# Altium schematic sheet FPGA Jtag & Uart.SchDoc("FPGA Jtag & Uart")
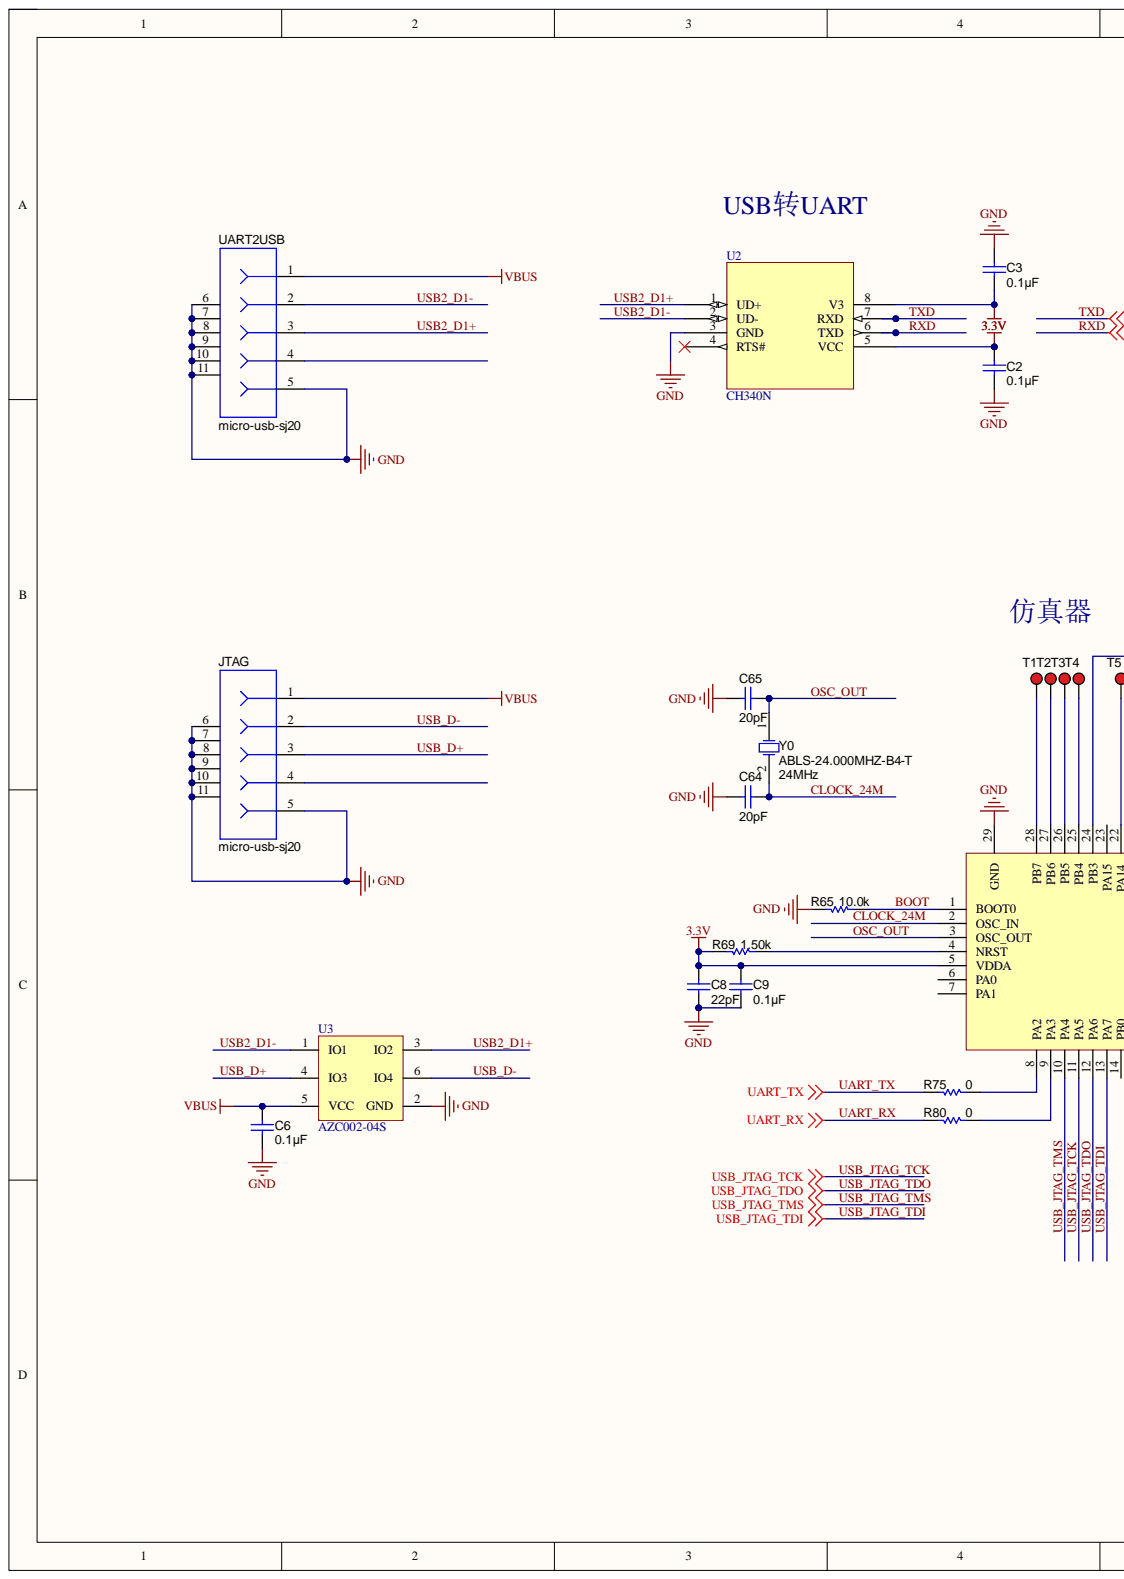
[diagram p0: FPGA Jtag & Uart.SchDoc, left]
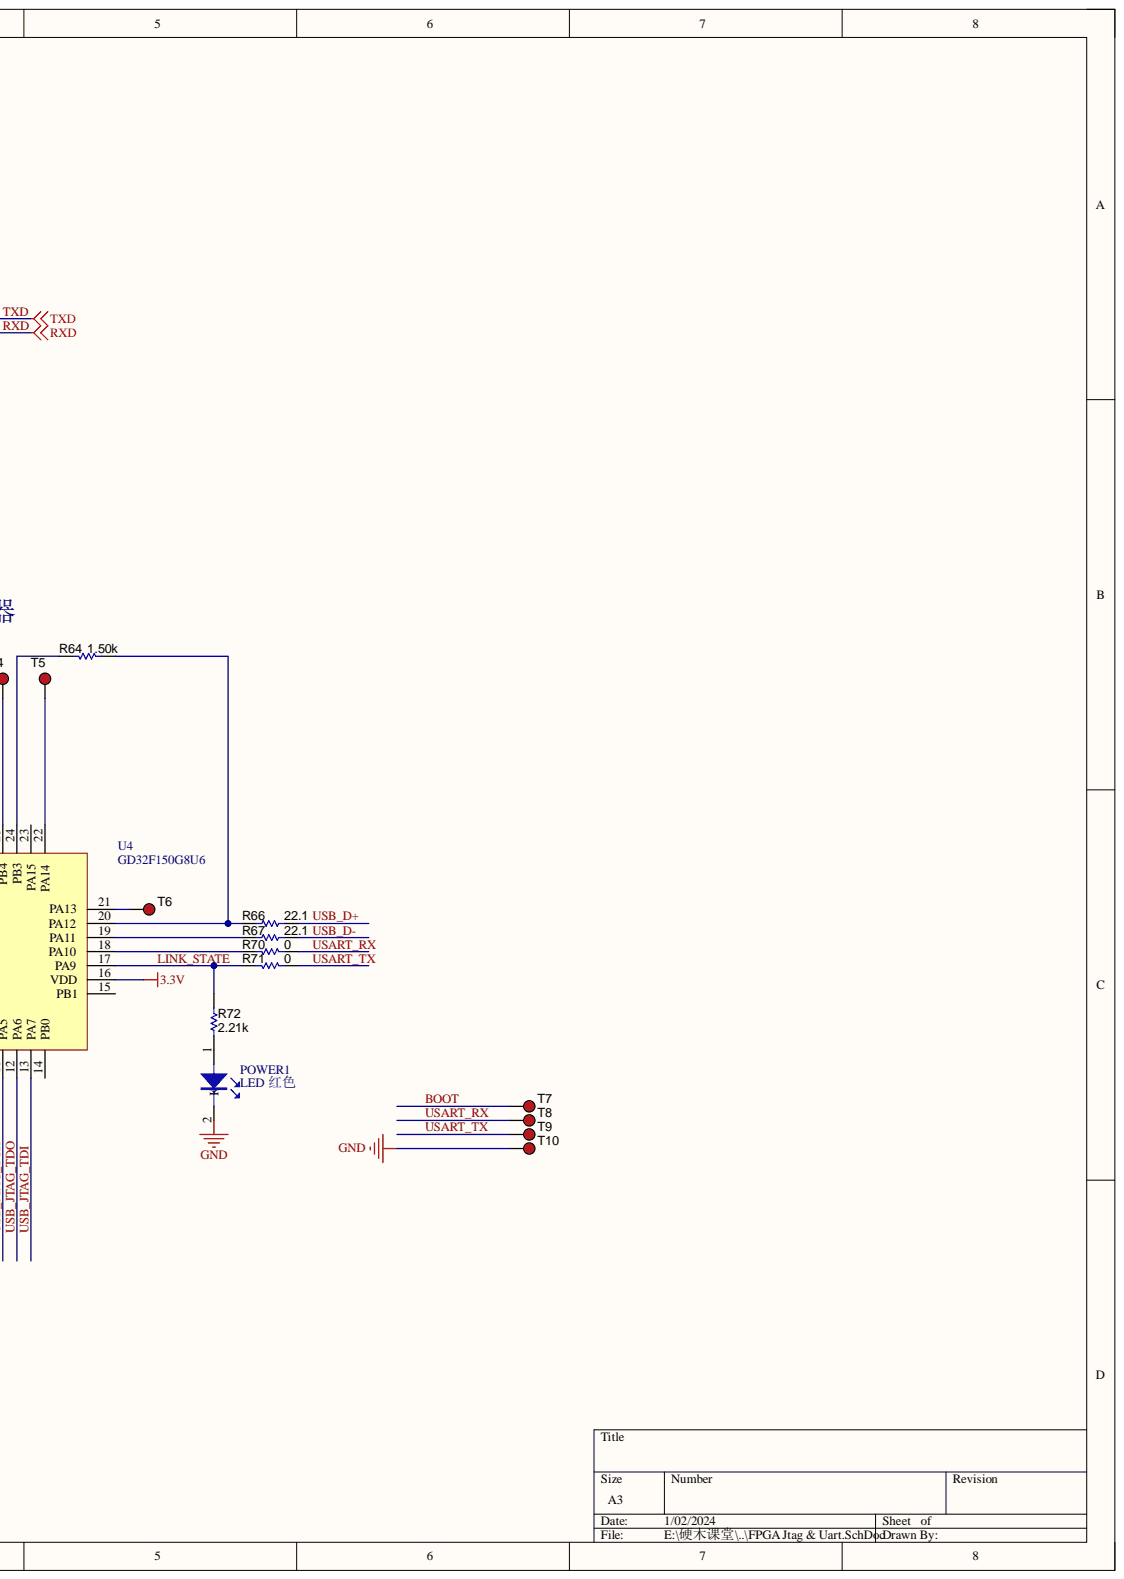
[diagram p1: FPGA Jtag & Uart.SchDoc, right]

## Parts
C2  ValueDisplayed: 0.1µF  [0402]  (hidden comment: C1005X7R1H104M)
C3  ValueDisplayed: 0.1µF  [0402]  (hidden comment: C1005X7R1H104M)
C6  ValueDisplayed: 0.1µF  [0402]  (hidden comment: C1005X7R1H104M)
C8  value 22pF  [0402]  (hidden comment: GRM1555C1H220FA01D)
C9  ValueDisplayed: 0.1µF  [0402]  (hidden comment: C1005X7R1H104M)
C64  value 20pF  [0402]  (hidden comment: GRM1555C1H200JA01D)
C65  value 20pF  [0402]  (hidden comment: GRM1555C1H200JA01D)
JTAG  micro-usb-sj20  [YQMICRO-USB-SJ20]
POWER1  LED 红色  [LED_LTST-C170]
R64  value 1.50k  [0402]  (hidden comment: CRCW04021K50FKED)
R65  value 10.0k  [0402]  (hidden comment: CRCW040210K0FKED)
R66  value 22.1  Res_ohm: 22.1  [0402]  (hidden comment: CRCW040222R1FKED)
R67  value 22.1  Res_ohm: 22.1  [0402]  (hidden comment: CRCW040222R1FKED)
R69  value 1.50k  [0402]  (hidden comment: CRCW04021K50FKED)
R70  value 0  [0402]  (hidden comment: CRCW04020000Z0ED)
R71  value 0  [0402]  (hidden comment: CRCW04020000Z0ED)
R72  value 2.21k  [0402]  (hidden comment: CRCW04022K21FKED)
R75  value 0  [0402]  (hidden comment: CRCW04020000Z0ED)
R80  value 0  [0402]  (hidden comment: CRCW04020000Z0ED)
T1  5000  [TP]  (value not drawn)
T2  5000  [TP]  (value not drawn)
T3  5000  [TP]  (value not drawn)
T4  5000  [TP]  (value not drawn)
T5  5000  [TP]  (value not drawn)
T6  5000  [TP]  (value not drawn)
T7  5000  [TP]  (value not drawn)
T8  5000  [TP]  (value not drawn)
T9  5000  [TP]  (value not drawn)
T10  5000  [TP]  (value not drawn)
U2  CH340N  [SOP8]
U3  AZC002-04S  [DBV0006A_N]
U4  GD32F150G8U6  [WQFN-28_L4.0-W4.0-P0.40-BL-EP]
UART2USB  micro-usb-sj20  [YQMICRO-USB-SJ20]
Y0  ABLS-24.000MHZ-B4-T  Frequency: 24MHz  [YQ_OSC5032]

## Nets
3.3V: C2.1 C3.2 C8.2 C9.1 R69.1 U2.5(VCC) U2.8(V3) U4.5(VDDA) U4.16(VDD)
GND: C2.2 C3.1 C6.2 C8.1 C9.2 C64.1 C65.1 JTAG.5 JTAG.6 JTAG.7 JTAG.8 JTAG.9 JTAG.10 JTAG.11 POWER1.2 R65.1 T10.1 U2.3(GND) U3.2(GND) U4.29(GND) UART2USB.5 UART2USB.6 UART2USB.7 UART2USB.8 UART2USB.9 UART2USB.10 UART2USB.11
VBUS: C6.1 JTAG.1 U3.5(VCC) UART2USB.1
BOOT: R65.2 T7.1 U4.1(BOOT0)
CLOCK_24M: C64.2 U4.2(OSC_IN) Y0.2
LINK_STATE: R71.1 R72.2 U4.17(PA9)
OSC_OUT: C65.2 U4.3(OSC_OUT) Y0.1
RXD: U2.6(TXD)
TXD: U2.7(RXD)
UART_RX: R80.1
UART_TX: R75.1
USART_RX: R70.2 T8.1
USART_TX: R71.2 T9.1
USB2_D1+: U2.1(UD+) U3.3(IO2) UART2USB.3
USB2_D1-: U2.2(UD-) U3.1(IO1) UART2USB.2
USB_D+: JTAG.3 R66.1 U3.4(IO3)
USB_D-: JTAG.2 R67.1 U3.6(IO4)
USB_JTAG_TCK: U4.11(PA5)
USB_JTAG_TDI: U4.13(PA7)
USB_JTAG_TDO: U4.12(PA6)
USB_JTAG_TMS: U4.10(PA4)
NetPOWER1_1: POWER1.1 R72.1
NetR64_1: R64.1 U4.24(PB3)
NetR64_2: R64.2 R66.2 U4.20(PA12)
NetR67_2: R67.2 U4.19(PA11)
NetR69_2: R69.2 U4.4(NRST)
NetR70_1: R70.1 U4.18(PA10)
NetR75_2: R75.2 U4.8(PA2)
NetR80_2: R80.2 U4.9(PA3)
NetT1_1: T1.1 U4.28(PB7)
NetT2_1: T2.1 U4.27(PB6)
NetT3_1: T3.1 U4.26(PB5)
NetT4_1: T4.1 U4.25(PB4)
NetT5_1: T5.1 U4.22(PA14)
NetT6_1: T6.1 U4.21(PA13)

Not connected: JTAG 4; U2 4(RTS#); U4 6(PA0) 7(PA1) 14(PB0) 15(PB1) 23(PA15); UART2USB 4

## Library data of the parts
C2 C3 C6 C9 = C1005X7R1H104M: CAP, CERM, 0.1 µF, 50 V, +/- 20%, X7R, 0402; Manufacturer: TDK; Cap_F: 0.0000001; CompType: Capacitor; Polarized: N; TaMax_C: 125; TaMin_C: -55; Tolerance_%: 20; U/M: EA; Value: 0.1uF; Value1: Unknown; Value2: 50V; VDC_V: 50; Cap_Case: 0402; Component Kind: Standard; ComponentLink1Description: Datasheet link; LatestRevisionDate: 2015-05-02; Library Name: Capacitor_Ceramic.IntLib; MountType: SMT; PackageReference: 0402; PartNumber: C1005X7R1H104M; Published: 2008-06-19; RoHS: Y; Signal Integrity: Cap; Simulation: Cap; Supplier 1: Digi-Key; Supplier 2: Farnell; Supplier Part Number 1: 445-5933-1-ND; Supplier Part Number 2: 1907278; SymbolVersion: 2008-07-09; Technology: Ceramic; TempCo: X7R; Publisher: Texas Instruments
C8 = GRM1555C1H220FA01D: CAP, CERM, 22 pF, 50 V, +/- 1%, C0G/NP0, 0402; Manufacturer: MuRata; Cap_F: 0.000000000022; CompType: Capacitor; Polarized: N; TaMax_C: 125; TaMin_C: -55; Tolerance_%: 1; U/M: EA; Value1: Unknown; Value2: 50V; VDC_V: 50; Cap_Case: 0402; Component Kind: Standard; ComponentLink1Description: Datasheet link; ComponentLink1URL: http://search.murata.co.jp/Ceramy/image/img/A01X/partnumbering_e_01.pdf; LatestRevisionDate: 2015-05-02; Library Name: Capacitor_Ceramic.IntLib; MountType: SMT; PackageReference: 0402; PartNumber: GRM1555C1H220FA01D; Published: 2008-06-19; RoHS: Y; Signal Integrity: Cap; Simulation: Cap; Supplier 1: Digi-Key; Supplier 2: Mouser; Supplier Part Number 1: 490-8589-1-ND; Supplier Part Number 2: 81-GRM1555C1H220FA1D; SymbolVersion: 2008-07-09; Technology: Ceramic; TempCo: C0G/NP0; ValueDisplayed: 22pF; Publisher: Texas Instruments
C64 C65 = GRM1555C1H200JA01D: CAP, CERM, 20 pF, 50 V, +/- 5%, C0G/NP0, 0402; Manufacturer: MuRata; Cap_F: 0.00000000002; CompType: Capacitor; Polarized: N; TaMax_C: 125; TaMin_C: -55; Tolerance_%: 5; U/M: EA; Value1: Unknown; Value2: 50V; VDC_V: 50; Cap_Case: 0402; Component Kind: Standard; ComponentLink1Description: Datasheet link; ComponentLink1URL: http://search.murata.co.jp/Ceramy/PnsearchViewAction.do?HnOFG=ON&HnNFG=ON&SEL_CNT=50&sLang=en&sKey=GRM1555C1H200JA01D&x=9&y=9&search=startwith; LatestRevisionDate: 2015-05-02; Library Name: Capacitor_Ceramic.IntLib; MountType: SMT; PackageReference: 0402; PartNumber: GRM1555C1H200JA01D; Published: 2008-06-19; RoHS: Y; Signal Integrity: Cap; Simulation: Cap; Supplier 1: Mouser; Supplier Part Number 1: 81-GRM1555C1H200JA1D; SymbolVersion: 2008-07-09; Technology: Ceramic; TempCo: C0G/NP0; ValueDisplayed: 20pF; Publisher: Texas Instruments
JTAG UART2USB = 105017-0001: Receptacle, Micro-USB-B, Right Angle, SMD; Manufacturer: Molex; CompType: Connector; SymbolCreator: a0412235; U/M: EA; Component Kind: Standard; ComponentLink1Description: Datasheet Link; ComponentLink1URL: http://www.molex.com/pdm_docs/sd/1050170001_sd.pdf; LatestRevisionDate: 2012-12-26; LatestRevisionNote: Added parts, moved some to Hardware.IntLib; Library Name: Connectors.IntLib; MountType: SMT; PackageReference: Micro USB receptacle; PartNumber: micro-usb-sj20; RoHS: Y; Supplier 1: Digi-Key; Supplier 2: Mouser; Supplier 3: Farnell; Supplier Part Number 1: WM1399CT-ND; Supplier Part Number 2: 538-105017-0001; Supplier Part Number 3: 1847433; SymbolVersion: 2012-12-19; Publisher: Texas Instruments
POWER1 = LED: LED 发光二极管; Component Kind: Standard; Library Name: WK_PCB.SchLib
R64 R69 = CRCW04021K50FKED: RES, 1.50 k, 1%, 0.063 W, 0402; Manufacturer: Vishay-Dale; CompType: Resistor; EIA_Code: E96; Power_W: 0.063; Res_ohm: 1500; TempCo: 100 ppm/C; Tolerance_%: 1; U/M: EA; Value2: 0.063W; Value_Code: 1k50; VDC_V: 50; Component Kind: Standard; ComponentLink1Description: Datasheet link; ComponentLink1URL: http://www.vishay.com/docs/20035/dcrcwe3.pdf; LatestRevisionDate: 2015-05-01; Library Name: Resistor_0402.IntLib; MountType: SMT; PackageReference: 0402; PartNumber: CRCW04021K50FKED; Published: 2008-06-26; RoHS: Y; Signal Integrity: Resistor; Simulation: Resistor; Supplier 1: Digi-Key; Supplier 2: Mouser; Supplier 3: Farnell; Supplier Part Number 1: 541-1.50KLCT-ND; Supplier Part Number 2: 71-CRCW0402-1.5K-E3; Supplier Part Number 3: 1653201; SymbolVersion: 2009-05-29; Technology: Thick Film; Value1: 1%; ValueDisplayed: 1.50k; Publisher: Texas Instruments
R65 = CRCW040210K0FKED: RES, 10.0 k, 1%, 0.063 W, 0402; Manufacturer: Vishay-Dale; CompType: Resistor; EIA_Code: E96; Power_W: 0.063; Res_ohm: 10000; TempCo: 100 ppm/C; Tolerance_%: 1; U/M: EA; Value2: 0.063W; Value_Code: 10k0; VDC_V: 50; Component Kind: Standard; ComponentLink1Description: Datasheet link; ComponentLink1URL: http://www.vishay.com/docs/20035/dcrcwe3.pdf; LatestRevisionDate: 2015-05-01; Library Name: Resistor_0402.IntLib; MountType: SMT; PackageReference: 0402; PartNumber: CRCW040210K0FKED; Published: 2008-06-26; RoHS: Y; Signal Integrity: Resistor; Simulation: Resistor; Supplier 1: Digi-Key; Supplier 2: Mouser; Supplier 3: Farnell; Supplier Part Number 1: 541-10.0KLCT-ND; Supplier Part Number 2: 71-CRCW0402-10K-E3; Supplier Part Number 3: 1469669; SymbolVersion: 2009-05-29; Technology: Thick Film; Value1: 1%; ValueDisplayed: 10.0k; Publisher: Texas Instruments
R66 R67 = CRCW040222R1FKED: RES, 22.1, 1%, 0.063 W, 0402; Manufacturer: Vishay-Dale; CompType: Resistor; EIA_Code: E96; Power_W: 0.063; TempCo: 100 ppm/C; Tolerance_%: 1; U/M: EA; Value2: 0.063W; Value_Code: 22R1; VDC_V: 50; Component Kind: Standard; ComponentLink1Description: Datasheet link; ComponentLink1URL: http://www.vishay.com/docs/20035/dcrcwe3.pdf; LatestRevisionDate: 2015-05-01; Library Name: Resistor_0402.IntLib; MountType: SMT; PackageReference: 0402; PartNumber: CRCW040222R1FKED; Published: 2008-06-26; RoHS: Y; Signal Integrity: Resistor; Simulation: Resistor; Supplier 1: Digi-Key; Supplier 2: Mouser; Supplier 3: Farnell; Supplier Part Number 1: 541-22.1LCT-ND; Supplier Part Number 2: 71-CRCW0402-22.1-E3; Supplier Part Number 3: 1469698; SymbolVersion: 2009-05-29; Technology: Thick Film; Value1: 1%; ValueDisplayed: 22.1; Publisher: Texas Instruments
R70 R71 R75 R80 = CRCW04020000Z0ED: RES, 0, 5%, 0.063 W, 0402; Manufacturer: Vishay-Dale; CompType: Resistor; EIA_Code: E24; Power_W: 0.063; TempCo: 200 ppm/C; Tolerance_%: 5; U/M: EA; Value2: 0.063W; Value_Code: 0000; VDC_V: 50; Component Kind: Standard; ComponentLink1Description: Datasheet link; ComponentLink1URL: http://www.vishay.com/docs/20035/dcrcwe3.pdf; LatestRevisionDate: 2015-05-01; Library Name: Resistor_0402.IntLib; MountType: SMT; PackageReference: 0402; PartNumber: CRCW04020000Z0ED; Published: 2008-06-26; Res_ohm: 0; RoHS: Y; Signal Integrity: Resistor; Simulation: Resistor; Supplier 1: Digi-Key; Supplier 2: Mouser; Supplier 3: Farnell; Supplier Part Number 1: 541-0.0JCT-ND; Supplier Part Number 2: 71-CRCW0402-0-E3; Supplier Part Number 3: 1469661; SymbolVersion: 2009-05-29; Technology: Thick Film; Value1: 5%; ValueDisplayed: 0; Publisher: Texas Instruments
R72 = CRCW04022K21FKED: RES, 2.21 k, 1%, 0.063 W, 0402; Manufacturer: Vishay-Dale; CompType: Resistor; EIA_Code: E96; Power_W: 0.063; Res_ohm: 2210; TempCo: 100 ppm/C; Tolerance_%: 1; U/M: EA; Value2: 0.063W; Value_Code: 2k21; VDC_V: 50; Component Kind: Standard; ComponentLink1Description: Datasheet link; ComponentLink1URL: http://www.vishay.com/docs/20035/dcrcwe3.pdf; LatestRevisionDate: 2015-05-01; Library Name: Resistor_0402.IntLib; MountType: SMT; PackageReference: 0402; PartNumber: CRCW04022K21FKED; Published: 2008-06-26; RoHS: Y; Signal Integrity: Resistor; Simulation: Resistor; Supplier 1: Digi-Key; Supplier 2: Mouser; Supplier 3: Farnell; Supplier Part Number 1: 541-2.21KLCT-ND; Supplier Part Number 2: 71-CRCW0402-2.21K-E3; Supplier Part Number 3: 1469689; SymbolVersion: 2009-05-29; Technology: Thick Film; Value1: 1%; ValueDisplayed: 2.21k; Publisher: Texas Instruments
T1-T10 = 5000: Test Point, TH, Miniature, Red; Manufacturer: Keystone; CompType: Test Point Loop; U/M: EA; Component Kind: Standard; ComponentLink1Description: Datasheet Link; ComponentLink1URL: http://www.keyelco.com/pdfs/M55p51.pdf; LatestRevisionDate: 2012-12-26; LatestRevisionNote: Added parts, moved some to Hardware.IntLib; Library Name: Connectors.IntLib; MountType: TH; PackageReference: Keystone5000; PartNumber: 5000; RoHS: Y; Supplier 1: Digi-Key; Supplier Part Number 1: 5000K-ND; SymbolVersion: 2008-04-04; Value: Red; Publisher: Texas Instruments
U2 = CH340N: USB to TTL Serial / UART; Component Kind: Standard
U3 = TPD4E001DBVR: Low-Capacitance + / - 15-kV ESD Protection Array for High-Speed Data Interfaces, 4 Channels, -40 to +85 degC, 6-pin SOT-23 (DBV), Green (RoHS & no Sb/Br); Alternate Manufacturer: None; Alternate PartNumber: Equivalent; Manufacturer: Texas Instruments; 1394 / Firewire: TRUE; Breakdown Voltage(Min)(V): 11; IEC 61000-4-2 Air-Gap(+/- kV): 15; IEC 61000-4-2 Contact(+/- kV): 8; IO Capacitance(Typ)(pF): 1.5; SubFamily: ESD Solution; Code_JEDEC: MO-178-AB*; Component Kind: Standard; ComponentLink1Description: Datasheet; ComponentLink1URL: http://www.ti.com/general/docs/lit/getliterature.tsp?genericPartNumber=TPD4E001&fileType=pdf; ComponentLink2Description: URL Link1; ComponentLink2URL: SamplesURL:http://www.ti.com/product/tpd4e001#samples; DatasheetVersion: SLLS682G; Eco-Plan: Green (RoHS & no Sb/Br); IO Leakage Current(nA): 1.5; Library Name: TI Interface ESD EMI Protection.IntLib; MountType: SMT; PackageDescription: 6-Pin Plastic Small-Outline Package, 2.9 x 1.6 mm Body, 0.95 mm Pitch, 2.8 mm Lead Span; PackageReference: DBV0006A; PackageVersion: revK, Mar-2006; PartNumber: TPD4E001DBVR; Serial ATA / eSATA: TRUE; Supplier 1: Digi-Key; Supplier 2: Digi-Key; Supplier 3: Digi-Key; Supplier 4: Newark; Supplier 5: Mouser; Supplier Part Number 1: 296-28203-2-ND; Supplier Part Number 2: 296-28203-1-ND; Supplier Part Number 3: 296-28203-6-ND; Supplier Part Number 4: 27T6836; Supplier Part Number 5: 595-TPD4E001DBVR; USB High Speed: TRUE
U4 = GD32F150U: Component Kind: Standard; ComponentLink1Description: Manufacturer URL; ComponentLink1URL: http://www.ti.com/; ComponentLink2Description: Datasheet; ComponentLink2URL: http://www.ti.com/general/docs/lit/getliterature.tsp?genericPartNumber=DAC904&fileType=pdf; ComponentLink3Description: Package Specification; ComponentLink3URL: http://www.ti.com/litv/pdf/mpds364; Description: GD32F150U; Library Name: YQPOWER.SchLib
Y0 = ABLS-8.000MHZ-B4-T: Crystal, 24MHz, 18pF, SMD,无源; Manufacturer: Abracon Corportation; Frequency Stability: ±50ppm; SymbolCreator: a0412235; TaMax_C: 70; TaMin_C: 0; U/M: EA; Component Kind: Standard; ComponentLink1Description: Datasheet Link; ComponentLink1URL: http://www.abracon.com/Resonators/abls.pdf; CompType: Crystal; LatestRevisionDate: 2015-05-05; Library Name: Oscillators.IntLib; MountType: SMT; PackageReference: Crystal, 11.4x4.3x3.8mm; PartNumber: ABLS-24.000MHZ-B4-T; RoHS: Y; Supplier 1: Digi-Key; Supplier 2: Mouser; Supplier Part Number 1: 535-10212-1-ND; Supplier Part Number 2: 815-ABLS-8.0M-T; SymbolVersion: 2008-07-09; Publisher: Texas Instruments
